# Altium schematic sheet power.SchDoc(power)
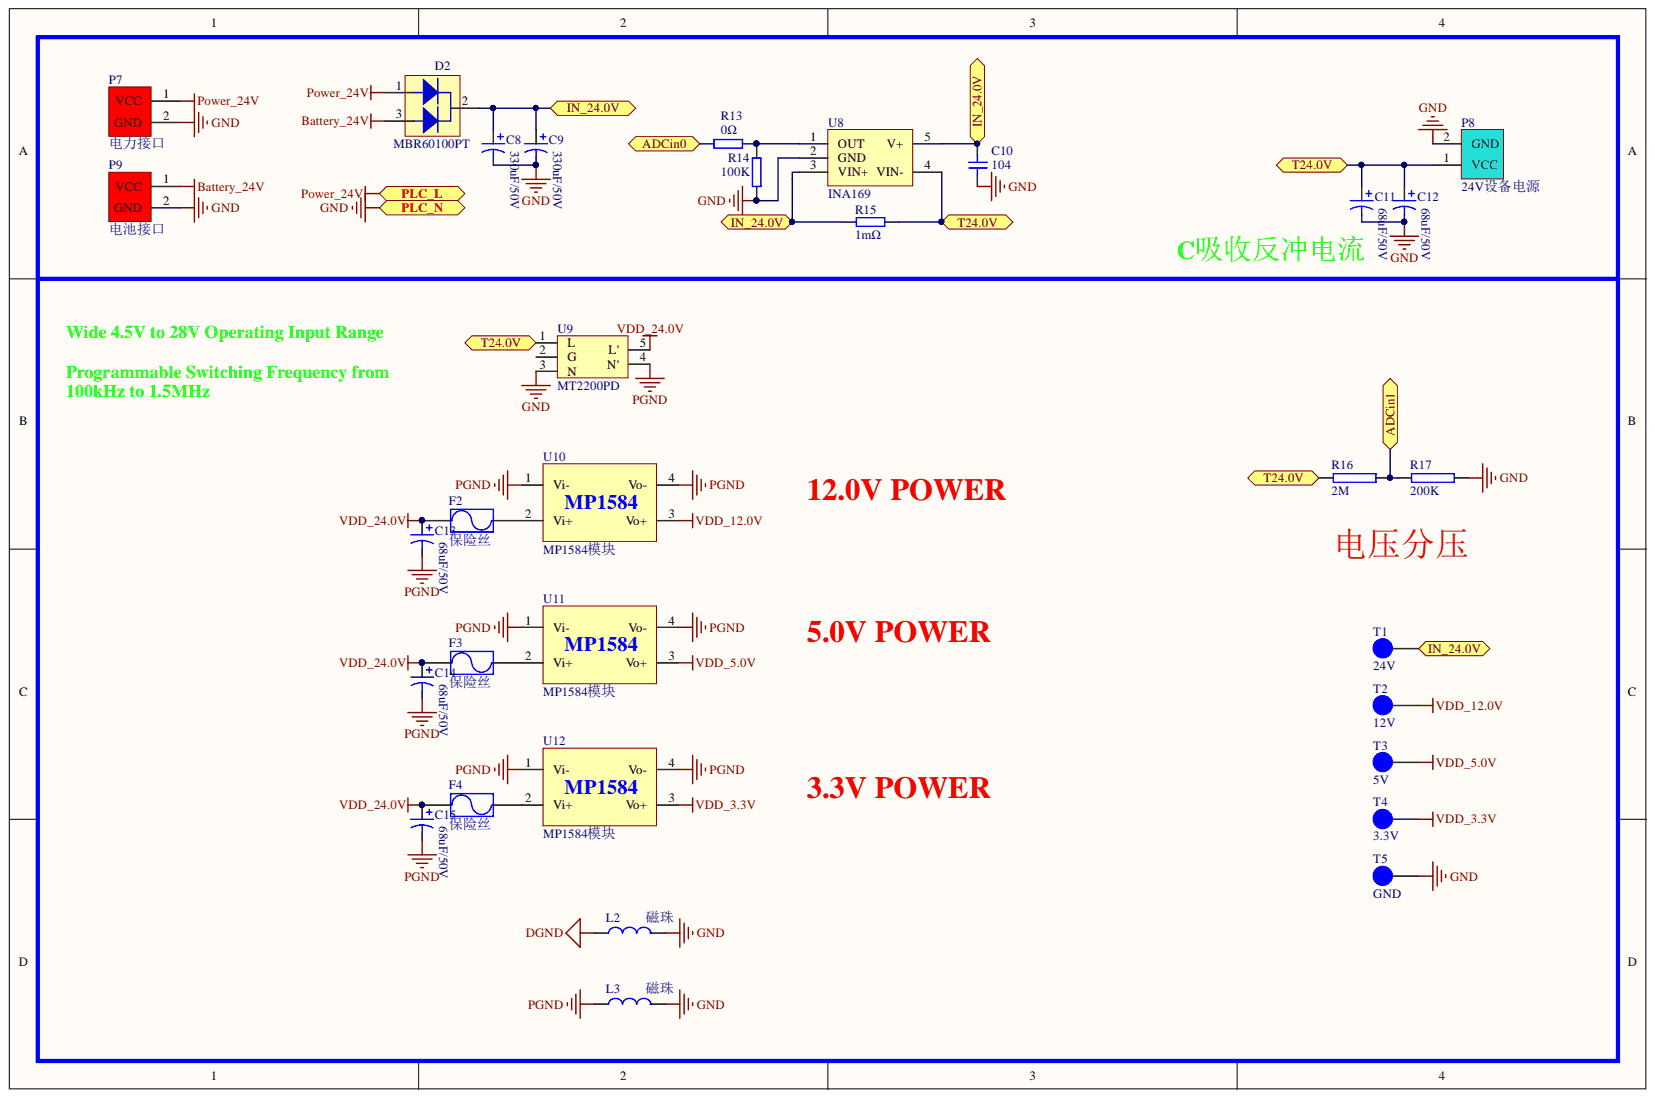
[diagram p0: power.SchDoc]

## Parts
C8  330uF/50V  [RB.2.4]
C9  330uF/50V  [RB.2.4]
C10  104  [0805C]
C11  68uF/50V  [RB.1.2]
C12  68uF/50V  [RB.1.2]
C13  68uF/50V  [RB.1.2]
C14  68uF/50V  [RB.1.2]
C15  68uF/50V  [RB.1.2]
D2  MBR60100PT  [TO-247LS]
F2  保险丝  [FUSE-1812]
F3  保险丝  [FUSE-1812]
F4  保险丝  [FUSE-1812]
L2  磁珠  [1206L]
L3  磁珠  [1206L]
P7  电力接口  [XT60-F]
P8  24V设备电源  [XT60-F]
P9  电池接口  [XT60-F]
R13  0Ω  [0805R]
R14  100K  [0805R]
R15  1mΩ  [2512R]
R16  2M  [0805R]
R17  200K  [0805R]
T1  24V  [HEADER 1]
T2  12V  [HEADER 1]
T3  5V  [HEADER 1]
T4  3.3V  [HEADER 1]
T5  GND  [HEADER 1]
U8  INA169  [SOT23-5]
U9  MT2200PD  [MT2200PD-6A]
U10  MP1584模块  [MP1584电源模块]
U11  MP1584模块  [MP1584电源模块]
U12  MP1584模块  [MP1584电源模块]

## Ports
ADCIN0, ADCIN1, IN_24.0V, PLC_L, PLC_N, T24.0V

## Nets
ADCIN0: R13.1 ; port ADCIN0
ADCIN1: R16.2 R17.1 ; port ADCIN1
BATTERY_24V: D2.3 P9.1
DGND: L2.1
PGND: C13.2 C14.2 C15.2 L3.1 U9.4(N') U10.1(Vi-) U10.4(Vo-) U11.1(Vi-) U11.4(Vo-) U12.1(Vi-) U12.4(Vo-)
VDD_3.3V: T4.1 U12.3(Vo+)
VDD_5.0V: T3.1 U11.3(Vo+)
VDD_12.0V: T2.1 U10.3(Vo+)
VDD_24.0V: C13.1 C14.1 C15.1 F2.1 F3.1 F4.1 U9.5(L')
GND: C8.2 C9.2 C10.1 C11.2 C12.2 L2.2 L3.2 P7.2 P8.2 P9.2 R14.2 R17.2 T5.1 U8.2(GND) U9.3(N) ; port PLC_N
IN_24.0V: C8.1 C9.1 C10.2 D2.2 R15.2 T1.1 U8.3(VIN+) U8.5(V+) ; port IN_24.0V
POWER_24V: D2.1 P7.1 ; port PLC_L
T24.0V: C11.1 C12.1 P8.1 R15.1 R16.1 U8.4(VIN-) U9.1(L) ; port T24.0V
NetF2_2: F2.2 U10.2(Vi+)
NetF3_2: F3.2 U11.2(Vi+)
NetF4_2: F4.2 U12.2(Vi+)
NetR13_2: R13.2 R14.1 U8.1(OUT)
N00165:
N00166:

Not connected: U9 2(G)

## Library data of the parts
C8 C9 C11-C15 = Cap3: 直插极性电容; Component Kind: Standard; Library Name: 集大电协1.5.SchLib
C10 = Cap1: 无极贴片电容; Component Kind: Standard; Library Name: 集大电协1.5.SchLib
F2-F4 = Fuse: Component Kind: Standard; Description: 保险丝; Library Name: 集大电协1.5.SchLib
L2 L3 = L: 电感; Component Kind: Standard; Library Name: 集大电协1.5.SchLib
P7 P9 = 电源端子: Component Kind: Standard; Library Name: 集大电协1.5.SchLib
P8 = 推进器电源: Component Kind: Standard; Library Name: 集大电协1.5.SchLib
R13-R15 = Res: 电阻; Component Kind: Standard; Library Name: 集大电协1.5.SchLib
R16 R17 = R1: Component Kind: Standard; Library Name: 窝牛器件封装.SchLib
T1-T5 = 测试点: Component Kind: Standard; Description: 测试点; Library Name: 集大电协1.2.SchLib
U10-U12 = MP1584电源模块: Component Kind: Standard; Library Name: 集大电协1.5.SchLib
D2 = MBR60100PT: Component Kind: Standard; Library Name: 集大电协1.5.SchLib
U8 = INA169: Component Kind: Standard; Library Name: 集大电协1.5.SchLib
U9 = MT2200PD: Component Kind: Standard; Library Name: 集大电协1.5.SchLib
